# Altium schematic sheet Ports.SchDoc(Ports)
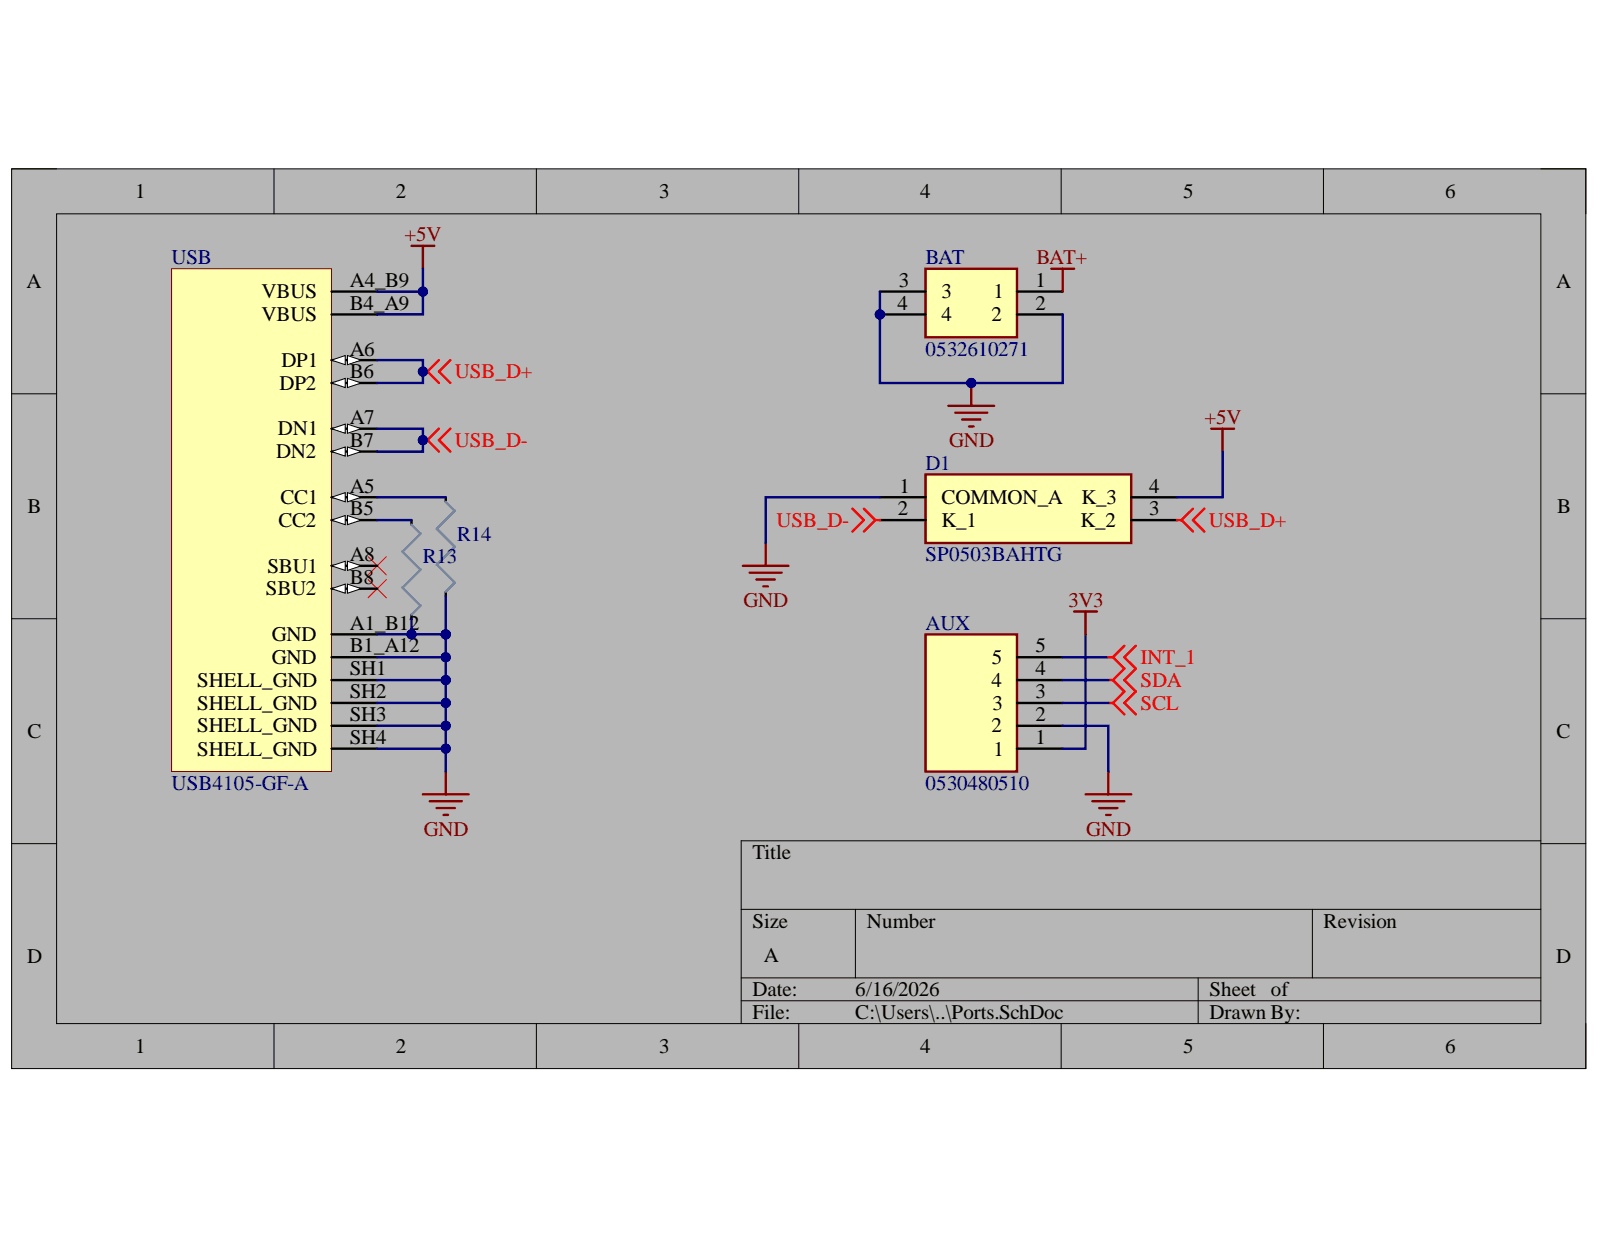
[diagram p0: Ports.SchDoc]

## Parts
AUX  0530480510  [0530480510]
BAT  0532610271  [0532610271]
D1  SP0503BAHTG  [SP0503BAHTG]
R13  5.1K 0402 Resistor  [0402_RESISTOR]  (value not drawn)
R14  5.1K 0402 Resistor  [0402_RESISTOR]  (value not drawn)
USB  USB4105-GF-A  [GCT_USB4105-GF-A]

## Nets
3V3: AUX.1
+5V: D1.4(K_3) USB.A4_B9(VBUS) USB.B4_A9(VBUS)
BAT+: BAT.1
GND: AUX.2 BAT.2 BAT.3 BAT.4 D1.1(COMMON_A) R13.1 R14.1 USB.A1_B12(GND) USB.B1_A12(GND) USB.SH1(SHELL_GND) USB.SH2(SHELL_GND) USB.SH3(SHELL_GND) USB.SH4(SHELL_GND)
INT_1: AUX.5
SCL: AUX.3
SDA: AUX.4
USB_D+: D1.3(K_2) USB.A6(DP1) USB.B6(DP2)
USB_D-: D1.2(K_1) USB.A7(DN1) USB.B7(DN2)
NetR13_2: R13.2 USB.B5(CC2)
NetR14_2: R14.2 USB.A5(CC1)

Not connected: USB A8(SBU1) B8(SBU2)

## Library data of the parts
AUX = 0530480510: Connector; Manufacturer_Name: Molex; Arrow Part Number: 0530480510; Arrow Price/Stock: https://www.arrow.com/en/products/0530480510/molex?region=nac; Component Kind: Standard; Datasheet Link: https://www.molex.com/pdm_docs/sd/530480510_sd.pdf; Height: 3.7mm; Library Name: SamacSys.SchLib; Manufacturer_Part_Number: 0530480510; Mouser Part Number: N/A; Mouser Price/Stock: https://www.mouser.co.uk/ProductDetail/Molex/0530480510?qs=PBcRNPEHKsq9e8OLOVrmnA%3D%3D
BAT = 0532610271: Connector; Manufacturer_Name: Molex; Arrow Part Number: 0532610271; Arrow Price/Stock: https://www.arrow.com/en/products/0532610271/molex?region=nac; Component Kind: Standard; Datasheet Link: http://www.molex.com/pdm_docs/sd/532610271_sd.pdf; Height: 3mm; Library Name: SamacSys.SchLib; Manufacturer_Part_Number: 0532610271; Mouser Part Number: N/A; Mouser Price/Stock: https://www.mouser.co.uk/ProductDetail/Molex/0532610271?qs=PBcRNPEHKsoFg%2F1Amqa4kA%3D%3D
D1 = SP0503BAHTG: TVS Diode (Uni-directional); Manufacturer_Name: LITTELFUSE; Arrow Part Number: SP0503BAHTG; Arrow Price/Stock: https://www.arrow.com/en/products/sp0503bahtg/littelfuse?region=nac; Component Kind: Standard; Datasheet Link: https://nl.mouser.com/datasheet/2/240/Littelfuse_TVS_Diode_Array_SP05_Datasheet.pdf-777043.pdf; Height: 1.22mm; Library Name: SamacSys.SchLib; Manufacturer_Part_Number: SP0503BAHTG; Mouser Part Number: 576-SP0503BAHTG; Mouser Price/Stock: https://www.mouser.co.uk/ProductDetail/Littelfuse/SP0503BAHTG?qs=uD%2FdkN7XIa0NTYXwHdELYA%3D%3D
R13 R14 = Resistor_0402: Component Kind: Standard; Library Name: custom.SchLib
USB = USB4105-GF-A: MF: {'manufacturer': u'GCT'}; Availability: Unavailable; Component Kind: Standard; Library Name: SamacSys.SchLib; MP: USB4105-GF-A; Package: None; Price: None
